# Altium schematic sheet MEZIFREKVENCE.SchDoc(MEZIFREKVENCE)
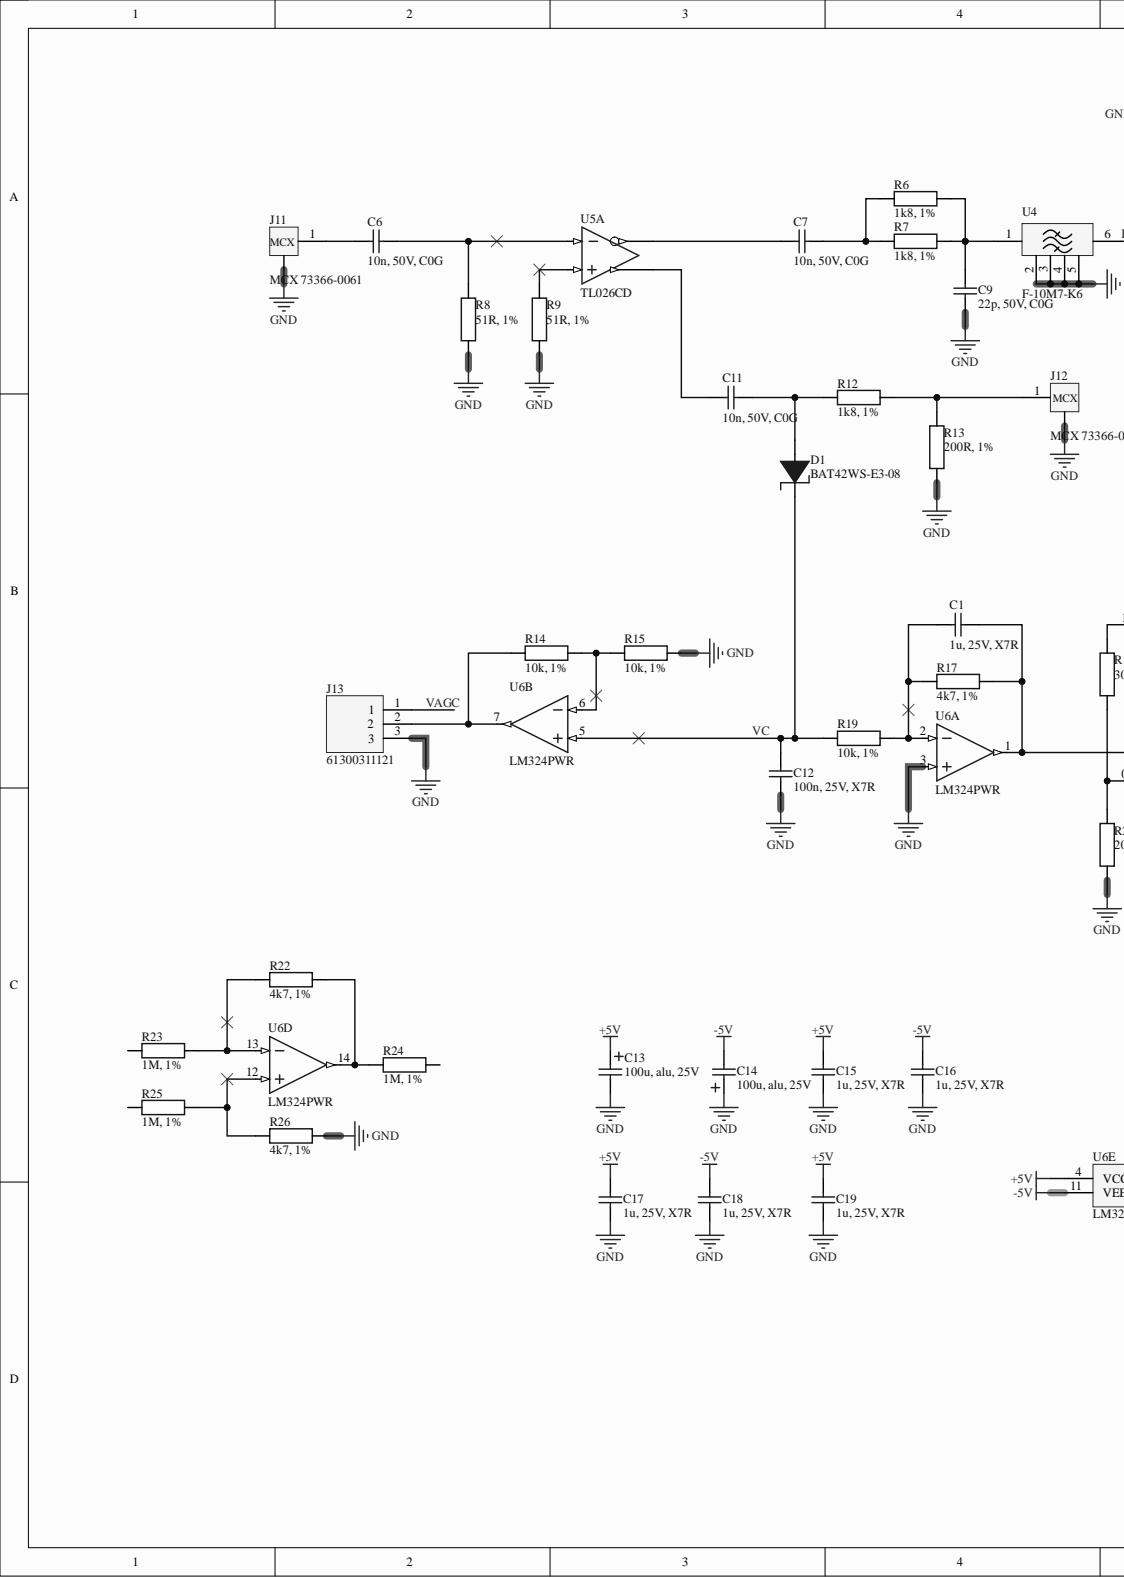
[diagram p0: MEZIFREKVENCE.SchDoc, left]
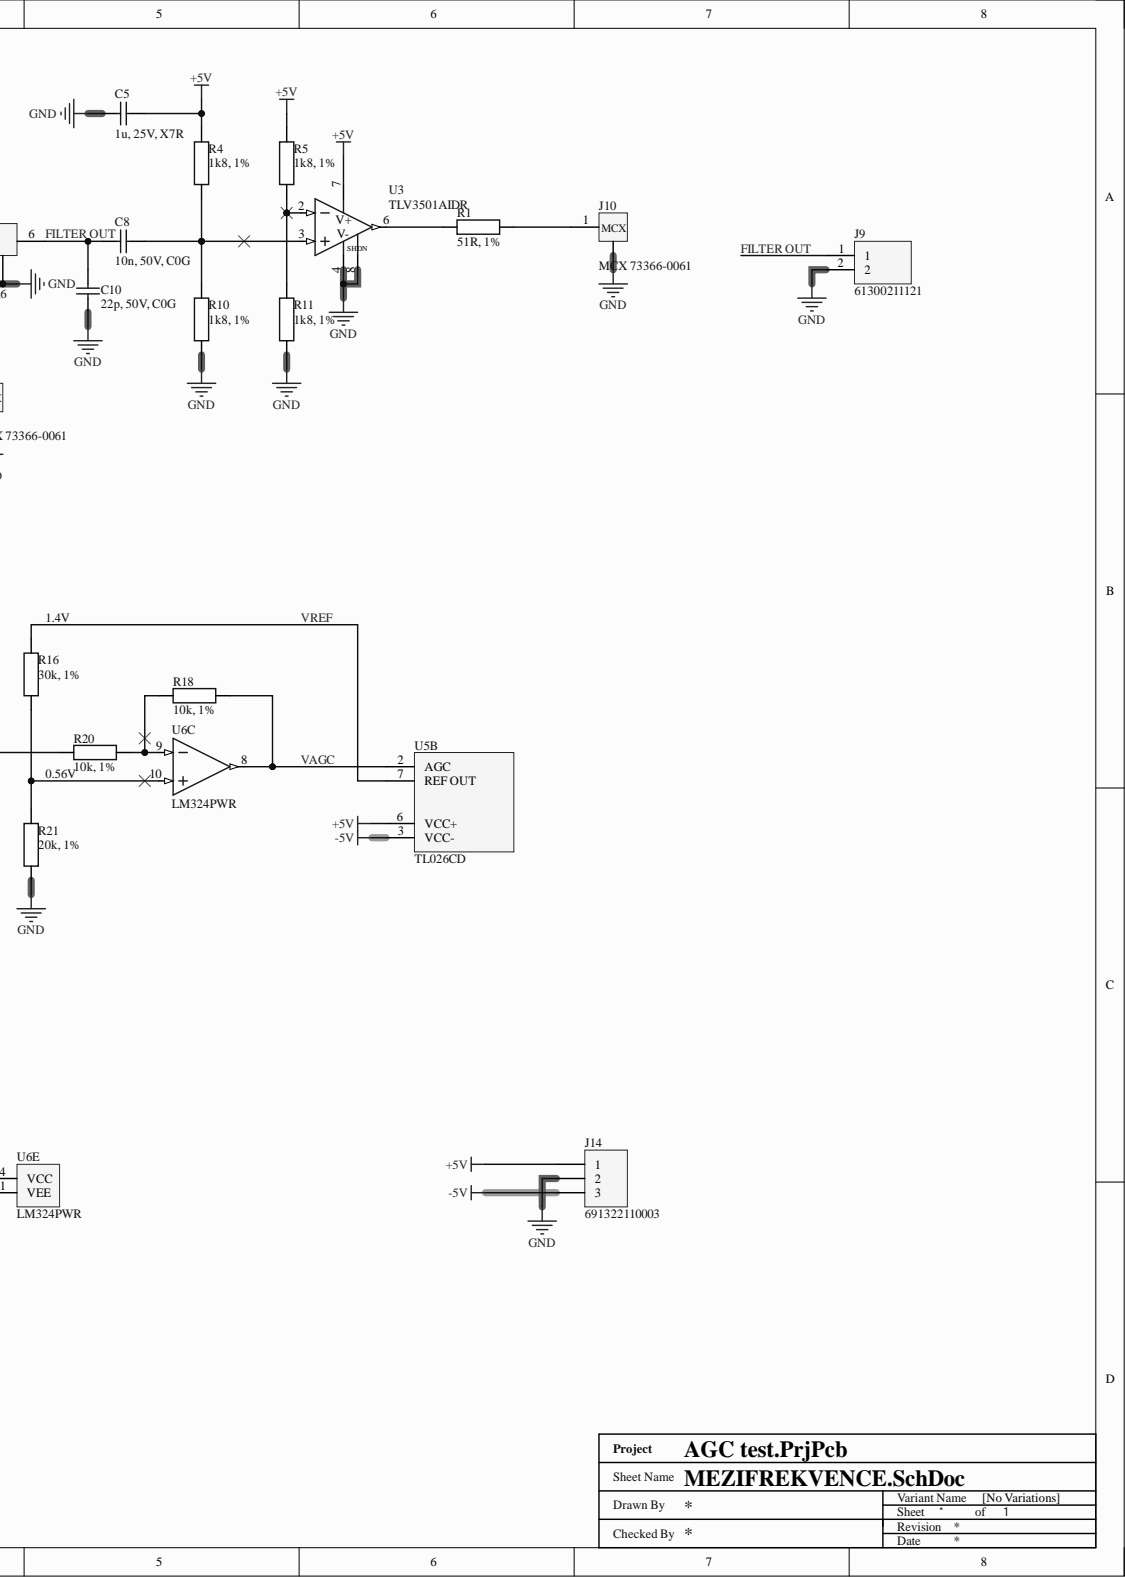
[diagram p1: MEZIFREKVENCE.SchDoc, right]

## Parts
C1  1u, 25V, X7R  Temperature Coefficient: X7R  [SMD0603]
C5  1u, 25V, X7R  [SMD0603]
C6  10n, 50V, C0G  [SMD0603]
C7  10n, 50V, C0G  [SMD0603]
C8  10n, 50V, C0G  [SMD0603]
C9  22p, 50V, C0G  [SMD0603]
C10  22p, 50V, C0G  [SMD0603]
C11  10n, 50V, C0G  [SMD0603]
C12  100n, 25V, X7R  [SMD0603]
C13  100u, alu, 25V  [WCAP-ATLI_6.3x11x2.5]
C14  100u, alu, 25V  Voltage Rating: 25V  [WCAP-ATLI_6.3x11x2.5]
C15  1u, 25V, X7R  Voltage - Rated: 25V  [SMD0603]
C16  1u, 25V, X7R  Temperature Coefficient: X7R  [SMD0603]
C17  1u, 25V, X7R  [SMD0603]
C18  1u, 25V, X7R  Temperature Coefficient: X7R  [SMD0603]
C19  1u, 25V, X7R  Temperature Coefficient: X7R  [SMD0603]
D1  BAT42WS-E3-08  [SOD-323]
J9  61300211121  [Header 2 2.54mm]
J10  MCX 73366-0061  Connector Style: MCX  [73366-0061]
J11  MCX 73366-0061  Connector Style: MCX  [73366-0061]
J12  MCX 73366-0061  Connector Style: MCX  [73366-0061]
J13  61300311121  [Header 3 2.54mm]
J14  691322110003  [691322110003]
R1  51R, 1%  [SMD0603 - R]
R4  1k8, 1%  Tolerance: 1%  [SMD0603 - R]
R5  1k8, 1%  [SMD0603 - R]
R6  1k8, 1%  Tolerance: 1%  [SMD0603 - R]
R7  1k8, 1%  Tolerance: 1%  [SMD0603 - R]
R8  51R, 1%  [SMD0603 - R]
R9  51R, 1%  [SMD0603 - R]
R10  1k8, 1%  Tolerance: 1%  [SMD0603 - R]
R11  1k8, 1%  Tolerance: 1%  [SMD0603 - R]
R12  1k8, 1%  Tolerance: 1%  [SMD0603 - R]
R13  200R, 1%  [SMD0603 - R]
R14  10k, 1%  [SMD0603 - R]
R15  10k, 1%  [SMD0603 - R]
R16  30k, 1%  Resistance Tolerance: 1%  [SMD0603 - R]
R17  4k7, 1%  [SMD0603 - R]
R18  10k, 1%  [SMD0603 - R]
R19  10k, 1%  [SMD0603 - R]
R20  10k, 1%  [SMD0603 - R]
R21  20k, 1%  [SMD0603 - R]
R22  4k7, 1%  [SMD0603 - R]
R23  1M, 1%  [SMD1206 - R]
R24  1M, 1%  [SMD1206 - R]
R25  1M, 1%  [SMD1206 - R]
R26  4k7, 1%  [SMD0603 - R]
U3  TLV3501AIDR  [SOIC08]
U4  F-10M7-K6  [F-10M7-K6]
U5  TL026CD  [SOIC08]  (parts A,B)
U6  LM324PWR  [TSSOP14]  (parts A,B,C,D,E)

## Nets
+5V: C5.2 C13.1 C15.2 C17.2 C19.2 J14.1 R4.2 R5.2 U3.7(V+) U5.6(VCC+) U6.4(VCC)
-5V: C14.2 C16.2 C18.2 J14.3 U5.3(VCC-) U6.11(VEE)
GND: C5.1 C9.1 C10.1 C12.1 C13.2 C14.1 C15.1 C16.1 C17.1 C18.1 C19.1 J9.2 J10.2 J11.2 J12.2 J13.3 J14.2 R8.1 R9.1 R10.1 R11.1 R13.1 R15.2 R21.1 R26.1 U3.4(V-) U3.8 U4.2 U4.3 U4.4 U4.5 U6.3
FILTER OUT: C8.1 C10.2 J9.1 U4.6
VAGC: J13.1 R18.2 U5.2(AGC) U6.8
VC: C12.2 D1.1 R19.1 U6.5
VREF: R16.2 U5.7(REF_OUT)
NetC1_1: C1.1 R17.2 R20.1 U6.1
NetC1_2: C1.2 R17.1 R19.2 U6.2
NetC6_1: C6.1 J11.1
NetC6_2: C6.2 R8.2 U5.8
NetC7_1: C7.1 U5.5
NetC7_2: C7.2 R6.2 R7.2
NetC8_2: C8.2 R4.1 R10.2 U3.3
NetC9_2: C9.2 R6.1 R7.1 U4.1
NetC11_1: C11.1 U5.4
NetC11_2: C11.2 D1.2 R12.1
NetJ10_1: J10.1 R1.2
NetJ12_1: J12.1 R12.2 R13.2
NetJ13_2: J13.2 R14.1 U6.7
NetR1_1: R1.1 U3.6
NetR5_1: R5.1 R11.2 U3.2
NetR9_2: R9.2 U5.1
NetR14_2: R14.2 R15.1 U6.6
NetR16_1: R16.1 R21.2 U6.10
NetR18_1: R18.1 R20.2 U6.9
NetR22_1: R22.1 R23.2 U6.13
NetR22_2: R22.2 R24.1 U6.14
NetR25_2: R25.2 R26.2 U6.12

Not connected: R23 1; R24 2; R25 1

## Library data of the parts
C1 C5 C15-C19 = CGA3E1X7R1E105K080AC: CAP CER 1UF 25V X7R 0603; Manufacturer: TDK Corporation; Tolerance: ±10%; Applications: Automotive; Capacitance: 1u; Category: Capacitors; Component Kind: Standard; ComponentLink1Description: https://product.tdk.com/info/en/catalog/datasheets/mlcc_automotive_general_en.pdf; ComponentLink1URL: https://product.tdk.com/info/en/catalog/datasheets/mlcc_automotive_general_en.pdf; Failure Rate: -; Features: -; Height - Seated (Max): -; Lead Spacing: -; Lead Style: -; Library Name: SMD0603Cap.IntLib; Manufacturer Part Number: CGA3E1X7R1E105K080AC; Mounting Type: Surface Mount, MLCC; Operating Temperature: -55°C ~ 125°C; Package / Case: 0603 (1608 Metric); Packaging: Cut Tape (CT); Part Status: Active; Pricing 1: 1=0.2, 10=0.135, 100=0.0686, 500=0.04696, 1000=0.04154 (USD); Ratings: AEC-Q200; RoHS: RoHS Compliant; Size / Dimension: 0.063" L x 0.032" W (1.60mm x 0.80mm); Stock 1: 109509; Supplier 1: Mouser; Supplier 2: Farnell; Supplier 3: Digi-Key; Supplier Part Number 1: 810-CGA3E1X7R1E105K; Supplier Part Number 2: 2346962; Supplier Part Number 3: 445-6931-1-ND; Thickness (Max): 0.035" (0.90mm)
  C1 C16 C18 C19: Voltage - Rated: 25V
  C5 C17: Temperature Coefficient: X7R; Voltage - Rated: 25V
  C15: Temperature Coefficient: X7R
C6-C8 C11 = GRM1885C1H103JA01D: Multilayer Ceramic Capacitors MLCC - SMD/SMT 0603 50VDC 10000pF 5% C0G; Brand: Murata Electronics; Capacitance - nF: 10 nF; Class: Class 1; CNHTS: 8532241000; Dielectric: C0G (NP0); TARIC: 8532240000; Type: Chip Multilayer Ceramic Capacitor for General Purpose; Capacitance: 10n; Capacitance - pF: 10000 pF; Case Code - in: 0603; Case Code - mm: 1608; Category: Multilayer Ceramic Capacitors MLCC - SMD/SMT; Component Kind: Standard; ComponentLink1Description: http://www.mouser.com/datasheet/2/281/murata_03052018_GRM_Series_1-1310166.pdf; ComponentLink1URL: http://www.mouser.com/datasheet/2/281/murata_03052018_GRM_Series_1-1310166.pdf; Height: 0.8 mm; HTS Code: 8532240020; Length: 1.6 mm; Library Name: SMD0603Cap.IntLib; Manufacturer: Murata Electronics; Manufacturer Part Number: GRM1885C1H103JA01D; Maximum Operating Temperature: + 125 C; Minimum Operating Temperature: - 55 C; Minimum Order Quantity: 1; Package / Case: 0603 (1608 metric); Packaging: Cut Tape; Pricing 1: 1=0.183, 10=0.129, 100=0.065, 500=0.045, 1000=0.039, 4000=0.030, 8000=0.027, 24000=0.025 (EUR); Product: General Type MLCCs; Product Type: Ceramic Capacitors; Reeling: True; RoHS: RoHS Compliant; RoHS - Mouser: Y; Series: GRM; Standard Pack Qty: 4000; Stock 1: 217291; Subcategory: Capacitors; Supplier 1: Mouser; Supplier 2: Farnell; Supplier Part Number 1: 81-GRM1885C1H103JA1D; Supplier Part Number 2: 2456107; Termination: Standard; Termination Style: SMD/SMT; Tolerance: 5 %; Voltage Rating DC: 50 VDC; Width: 0.8 mm
C9 C10 = MC0603N220J500CT: MULTICOMP - MC0603N220J500CT - CAP, MLCC, C0G/NP0, 22PF, 50V, 0603; Capacitance: 22p; Component Kind: Standard; ComponentLink1Description: http://www.farnell.com/datasheets/1901289.pdf; ComponentLink1URL: http://www.farnell.com/datasheets/1901289.pdf; Library Name: SMD0603Cap.IntLib; Manufacturer: MULTICOMP; Manufacturer Part Number: MC0603N220J500CT; Pricing 1: 10=0.0077, 100=0.0065, 500=0.0052, 1000=0.0044, 2000=0.0038 (GBP); RoHS: YES; Stock 1: 184439; Supplier 1: Farnell; Supplier 2: Newark; Supplier Part Number 1: 1759057; Supplier Part Number 2: 94W8766
C12 = 06033C104KAT2A: AVX - 06033C104KAT2A - CAP, MLCC, X7R, 0.1UF, 25V, 0603; Capacitance Tolerance: ± 10%; Ceramic Capacitor Case: 0603 [1608 Metric]; Dielectric Characteristic: X7; Product Range: AVX 0603 MLCC; SVHC: No SVHC (17-Dec-2015)<!--FANDB--><div class="features-and-benefits-container"><div class="features-and-benefits-desc-container"><span class="features-and-benefits-container-desc">SMD Multilayer Ceramic Capacitor, 0603 [1608 Metric], 0.1 µF, 25 V, ± 10%, X7R, AVX 0603 MLCC</span></div><div class="features-and-benefits-description"><span class="features-benefits-value">The 06033C104KAT2A is a SMD Multilayer Ceramic Capacitor with Ni/Sn-plated termination, X7R dielectric and 0603 case. X7R formulations are called temperature stable ceramics and fall into EIA class II materials. X7R is the most popular of this intermediate dielectric constant material. This capacitance change is non-linear. Capacitance for X7R varies under the influence of electrical operating conditions such as voltage and frequency. X7; Voltage Rating: 25; Automotive Qualification Standard: -; Capacitance: 100n; Component Kind: Standard; ComponentLink1Description: http://www.farnell.com/datasheets/2237835.pdf; ComponentLink1URL: http://www.farnell.com/datasheets/2237835.pdf; Library Name: SMD0603Cap.IntLib; Manufacturer: AVX; Manufacturer Part Number: 06033C104KAT2A; MSL: MSL 1 - Unlimited; Operating Temperature Max: 125; Operating Temperature Min: -55; Packaging: Cut Tape; Pricing 1: 10=0.012, 100=0.0096, 500=0.0069, 1000=0.006, 2000=0.0048, 4000=0.0038, 20000=0.0027, 40000=0.0026 (GBP); RoHS: YES; Stock 1: 22809; Supplier 1: Farnell; Supplier 2: Digi-Key; Supplier Part Number 1: 2530141; Supplier Part Number 2: 478-3714-1-ND
C13 C14 = 860010473007: CAP 100 UF 20% 25 V; Manufacturer: Wurth Electronics; Capacitance: 100uF; Life (Hours): 2000Hour; Material: Aluminium; Polarity: Polar; Ripple Current: 209mA; Case/Package: Radial; Category: Aluminum Electrolytic Capacitors; Component Kind: Standard; ComponentLink1Description: https://datasheet.ciiva.com/48693/001280151-da-01-en-wcap-atg8-alu-elec-caps-860010473007-48693591.pdf?src-supplier=Conrad; ComponentLink1URL: https://datasheet.ciiva.com/48693/001280151-da-01-en-wcap-atg8-alu-elec-caps-860010473007-48693591.pdf?src-supplier=Conrad; Diameter: 6.3mm; Height: 11mm; Height - Seated (Max): 0.492inch; Lead Pitch: 0.098inch; Library Name: Capacitors.IntLib; Manufacturer Part Number: 860010473007; Max Operating Temperature: 85°C; Min Operating Temperature: -40°C; Mount: Through Hole; Package Quantity: 1500; Packaging: Tape and Reel; Pricing 1: 1=0.14000, 10=0.13400, 25=0.13400, 50=0.11200, 100=0.09800, 250=0.08700 (USD); REACH SVHC: Unknown; RoHS: Yes; Stock 1: 1494; Supplier 1: Mouser; Supplier 2: Farnell; Supplier 3: Digi-Key; Supplier Part Number 1: 710-860010473007; Supplier Part Number 2: 2465675; Supplier Part Number 3: 732-8630-1-ND; Tolerance: 20%; Voltage Rating (DC): 25V
  C13: Voltage Rating: 25V
D1 = BAT42WS-E3-08: DIODE SCHOTTKY 30V 200MA SOD323; Manufacturer: Vishay Semiconductor Diodes Division; Capacitance @ Vr, F: 7pF @ 1V, 1MHz; Current - Average Rectified (Io): 200mA (DC); Speed: Small Signal =< 200mA (Io), Any Speed; Category: Discrete Semiconductor Products; Component Kind: Standard; ComponentLink1Description: http://www.vishay.com/docs/81813/bat42wsv.pdf; ComponentLink1URL: http://www.vishay.com/docs/81813/bat42wsv.pdf; Diode Type: Schottky; Library Name: Diodes.IntLib; Manufacturer Part Number: BAT42WS-E3-08; Mounting Type: Surface Mount; Operating Temperature - Junction: 125°C (Max); Package / Case: SC-76, SOD-323; Packaging: Tape & Reel (TR); Part Status: Active; Pricing 1: 15000=0.0504 (USD); RoHS: RoHS Compliant; Stock 1: 0; Supplier 1: Digi-Key; Supplier 2: Mouser; Supplier Device Package: SOD-323; Supplier Part Number 1: BAT42WS-E3-08-ND; Supplier Part Number 2: 78-BAT42WS-E3-08
J9 = 61300211121: CONN HEADER 2 POS 2.54; Manufacturer: Wurth Electronics Inc.; Contact Length - Mating: 0.236" (6.00mm); Contact Length - Post: 0.118" (3.00mm); Current Rating: 3A; Material Flammability Rating: UL94 V-0; Number of Positions: 2; Number of Positions Loaded: All; Overall Contact Length: 0.454" (11.54mm); Pitch - Mating: 0.100" (2.54mm); Shrouding: Unshrouded; Style: Board to Board or Cable; Voltage Rating: 250V; Applications: -; Category: Connectors, Interconnects; Component Kind: Standard; ComponentLink1Description: https://katalog.we-online.de/em/datasheet/6130xx11121.pdf; ComponentLink1URL: https://katalog.we-online.de/em/datasheet/6130xx11121.pdf; Connector Type: Header; Contact Finish - Mating: Gold; Contact Finish - Post: -; Contact Finish Thickness - Mating: -; Contact Finish Thickness - Post: -; Contact Material: Copper Alloy; Contact Shape: Square; Contact Type: Male Pin; Fastening Type: Push-Pull; Features: -; Ingress Protection: -; Insulation Color: Black; Insulation Height: 0.100" (2.54mm); Insulation Material: Polybutylene Terephthalate (PBT); Library Name: Connectors.IntLib; Manufacturer Part Number: 61300211121; Mated Stacking Heights: -; Mounting Type: Through Hole; Number of Rows: 1; Operating Temperature: -40°C ~ 125°C; Packaging: Bulk; Part Status: Active; Pricing 1: 1=0.13, 10=0.106, 25=0.088, 50=0.074, 100=0.067, 1000=0.061 (USD); RoHS: RoHS Compliant; Row Spacing - Mating: -; Stock 1: 164911; Supplier 1: Mouser; Supplier 2: Farnell; Supplier 3: Digi-Key; Supplier Part Number 1: 710-61300211121; Supplier Part Number 2: 2356153; Supplier Part Number 3: 732-5315-ND; Termination: Solder
J10-J12 = 73366-0061: CONN MCX JACK STR 50 OHM PCB; Manufacturer: Molex, LLC; Manufacturer Part Number: 0733660061; Body Finish: Gold; Body Material: Brass; Center Contact Material: Beryllium Copper; Connector Type: Jack, Female Socket; Contact Termination: Solder; Dielectric Material: Polytetrafluoroethylene (PTFE); Impedance: 50 Ohm; Mating Cycles: 500; Cable Group: -; Category: Connectors, Interconnects; Center Contact Plating: Gold; Component Kind: Standard; ComponentLink1Description: https://www.molex.com/pdm_docs/sd/733660061_sd.pdf; ComponentLink1URL: https://www.molex.com/pdm_docs/sd/733660061_sd.pdf; Fastening Type: Snap-On; Features: -; Housing Color: Gold; Includes: -; Ingress Protection: -; Insertion Loss: -; Library Name: Connectors.IntLib; Mounting Feature: -; Mounting Type: Through Hole; Operating Temperature: -55°C ~ 155°C; Packaging: Tray; Part Status: Active; Pricing 1: 1=1.95, 10=1.765, 25=1.6572, 50=1.5854, 100=1.5133, 250=1.36916, 500=1.26106, 1000=1.0809, 2500=1.00884 (USD); RoHS: RoHS Compliant; Shield Termination: Solder; Stock 1: 39394; Supplier 1: Mouser; Supplier 2: Digi-Key; Supplier 3: Farnell; Supplier Part Number 1: 538-73366-0061; Supplier Part Number 2: WM5541-ND; Supplier Part Number 3: 1909245
J13 = 61300311121: CONN HEADER 3 POS 2.54; Manufacturer: Wurth Electronics Inc.; Contact Length - Mating: 0.236" (6.00mm); Contact Length - Post: 0.118" (3.00mm); Current Rating: 3A; Material Flammability Rating: UL94 V-0; Number of Positions: 3; Number of Positions Loaded: All; Overall Contact Length: 0.454" (11.54mm); Pitch - Mating: 0.100" (2.54mm); Shrouding: Unshrouded; Style: Board to Board or Cable; Voltage Rating: 250V; Applications: -; Category: Connectors, Interconnects; Component Kind: Standard; ComponentLink1Description: https://katalog.we-online.de/em/datasheet/6130xx11121.pdf; ComponentLink1URL: https://katalog.we-online.de/em/datasheet/6130xx11121.pdf; Connector Type: Header; Contact Finish - Mating: Gold; Contact Finish - Post: -; Contact Finish Thickness - Mating: -; Contact Finish Thickness - Post: -; Contact Material: Copper Alloy; Contact Shape: Square; Contact Type: Male Pin; Fastening Type: Push-Pull; Features: -; Ingress Protection: -; Insulation Color: Black; Insulation Height: 0.100" (2.54mm); Insulation Material: Polybutylene Terephthalate (PBT); Library Name: Connectors.IntLib; Manufacturer Part Number: 61300311121; Mated Stacking Heights: -; Mounting Type: Through Hole; Number of Rows: 1; Operating Temperature: -40°C ~ 125°C; Packaging: Bulk; Part Status: Active; Pricing 1: 1=0.13, 10=0.106, 25=0.088, 50=0.074, 100=0.067, 1000=0.061 (USD); RoHS: RoHS Compliant; Row Spacing - Mating: -; Stock 1: 222317; Supplier 1: Mouser; Supplier 2: Farnell; Supplier 3: Digi-Key; Supplier Part Number 1: 710-61300311121; Supplier Part Number 2: 2356154; Supplier Part Number 3: 732-5316-ND; Termination: Solder
J14 = 691322110003: WR-TBL Serie 3221 - 3.50 mm Horizontal PCB Header, 03p; Pitch: 3.5 mm; Component Kind: Standard; ComponentLink1Description: Manufacturer; ComponentLink1URL: http://www.we-online.de; ComponentLink2Description: Datasheet; ComponentLink2URL: http://katalog.we-online.de/em/datasheet/6913221100xx.pdf; Library Name: Connectors.IntLib; Part Number: 691322110003; Pin Number: 03p; Published Date: Jan 2017; Supplier 1: Digi-Key; Supplier 2: Farnell; Supplier Part Number 1: 732-2770-ND; Supplier Part Number 2: 1841316; Publisher: Wurth Elektronik
R1 R8 R9 = CRCW060351R0FKEA: RES SMD 51 OHM 1% 1/10W 0603; Manufacturer: Vishay Dale; Power (Watts): 0.1W, 1/10W; Temperature Coefficient: ±100ppm/°C; Category: Resistors; Component Kind: Standard; ComponentLink1Description: http://www.vishay.com/docs/20035/dcrcwe3.pdf; ComponentLink1URL: http://www.vishay.com/docs/20035/dcrcwe3.pdf; Composition: Thick Film; Failure Rate: -; Features: Automotive AEC-Q200; Height - Seated (Max): 0.020" (0.50mm); Library Name: SMD0603Res.IntLib; Manufacturer Part Number: CRCW060351R0FKEA; Operating Temperature: -55°C ~ 155°C; Package / Case: 0603 (1608 Metric); Packaging: Cut Tape (CT); Part Status: Active; Pricing 1: 1=0.1, 10=0.042, 100=0.017, 1000=0.00764, 2500=0.00663 (USD); Resistance: 51R; RoHS: RoHS Compliant; Size / Dimension: 0.063" L x 0.033" W (1.60mm x 0.85mm); Stock 1: 21691; Supplier 1: Mouser; Supplier 2: Farnell; Supplier 3: Digi-Key; Supplier Device Package: 0603; Supplier Part Number 1: 71-CRCW0603-51-E3; Supplier Part Number 2: 1652889; Supplier Part Number 3: 541-51.0HCT-ND; Tolerance: ±1%
R4-R7 R10-R12 = RC0603FR-071K8L: Res Thick Film 0603 1.8K Ohm 1% 0.1W(1/10W) ±100ppm/C Molded SMD Paper T/R; Manufacturer: Yageo; Max Power Dissipation: 100mW; Temperature Coefficient: 100ppm/°C; Voltage Rating: 50V; Case Code (Imperial): 0603; Case Code (Metric): 1608; Case/Package: 0603; Category: Chip SMD Resistors; Component Kind: Standard; ComponentLink1Description: https://datasheet.ciiva.com/11808/0900766b80f96399-11808105.pdf?src-supplier=RSComponents; ComponentLink1URL: https://datasheet.ciiva.com/11808/0900766b80f96399-11808105.pdf?src-supplier=RSComponents; ComponentLink2Description: https://datasheet.ciiva.com/2519/pyu-r-int-thick-7-2519189.pdf?src-supplier=Digi-Key; ComponentLink2URL: https://datasheet.ciiva.com/2519/pyu-r-int-thick-7-2519189.pdf?src-supplier=Digi-Key; ComponentLink3Description: https://datasheet.ciiva.com/7746/443971-7746277.pdf?src-supplier=Element14; ComponentLink3URL: https://datasheet.ciiva.com/7746/443971-7746277.pdf?src-supplier=Element14; ComponentLink4Description: https://datasheet.ciiva.com/2519/pyu-r-int-thick-7-2519190.pdf?src-supplier=Digi-Key; ComponentLink4URL: https://datasheet.ciiva.com/2519/pyu-r-int-thick-7-2519190.pdf?src-supplier=Digi-Key; ComponentLink5Description: https://datasheet.ciiva.com/7746/443971-7746278.pdf?src-supplier=Element14; ComponentLink5URL: https://datasheet.ciiva.com/7746/443971-7746278.pdf?src-supplier=Element14; ComponentLink6Description: https://datasheet.ciiva.com/12558/rc0603-272842-12558694.pdf?src-supplier=Mouser; ComponentLink6URL: https://datasheet.ciiva.com/12558/rc0603-272842-12558694.pdf?src-supplier=Mouser; ComponentLink7Description: https://datasheet.ciiva.com/2519/pyu-r-int-thick-7-2519191.pdf?src-supplier=Digi-Key; ComponentLink7URL: https://datasheet.ciiva.com/2519/pyu-r-int-thick-7-2519191.pdf?src-supplier=Digi-Key; Composition: Thick Film; Depth: 0.8mm; Features: Moisture Resistant; Height: 0.45mm; Lead Free: Lead Free; Length: 1.6mm; Library Name: SMD0603Res.IntLib; Manufacturer Part Number: RC0603FR-071K8L; Max Operating Temperature: 155°C; Military Standard: Not; Min Operating Temperature: -55°C; Number of Pins: 2; Number of Terminations: 2; Package Quantity: 5000; Packaging: Tape and Reel; Power Rating: 100mW; Pricing 1: 1=0,10000, 10=0,01800, 100=0,00600, 1000=0,00500, 5000=0,00300, 10000=0,00300 (USD); REACH SVHC: No SVHC; Resistance: 1k8; RoHS: Yes; Stock 1: 505177; Supplier 1: Mouser; Supplier 2: Digi-Key; Supplier 3: Farnell; Supplier Part Number 1: 603-RC0603FR-071K8L; Supplier Part Number 2: 311-1.80KHRCT-ND; Supplier Part Number 3: 2693664; Termination: SMD/SMT; Voltage Rating (DC): 50V; Width: 0.8mm
  R5: Tolerance: 1%
R13 = RC0603FR-13200RL: Res Thick Film 0603 200 Ohm 1% 0.1W(1/10W) ±100ppm/C Molded SMD Paper T/R; Manufacturer: Yageo; Power Rating: 100mW; Temperature Coefficient: 100ppm/°C; Voltage Rating: 50V; Case Code (Imperial): 0603; Case Code (Metric): 1608; Case/Package: 0603; Category: Chip SMD Resistors; Component Kind: Standard; ComponentLink1Description: https://datasheet.ciiva.com/12562/rc0603-272842-12562026.pdf?src-supplier=Mouser; ComponentLink1URL: https://datasheet.ciiva.com/12562/rc0603-272842-12562026.pdf?src-supplier=Mouser; Construction: Rectangular; Contact Plating: Tin,Matte; Depth: 0.8mm; Height: 0.45mm; Length: 1.6mm; Library Name: SMD0603Res.IntLib; Manufacturer Part Number: RC0603FR-13200RL; Max Operating Temperature: 155°C; Military Standard: Not; Min Operating Temperature: -55°C; Packaging: Tape and Reel; Pricing 1: 1=0,10000, 10=0,01800, 50=0,01800, 100=0,00600, 1000=0,00500, 10000=0,00400 (USD); Radiation Hardening: No; Resistance: 200R; Resistor Type: Thick Film; RoHS: Yes; RoHS Compliant: Yes; Series: RC; Stock 1: 45325; Supplier 1: Mouser; Supplier 2: Arrow Electronics; Supplier Part Number 1: 603-RC0603FR-13200RL; Supplier Part Number 2: RC0603FR-13200RL; Termination: SMD/SMT; Tolerance: 1%; Width: 0.8mm
R14 R15 R18-R20 = RC0603FR-0710KL: YAGEO (PHYCOMP) - RC0603FR-0710KL - RES, THICK FILM, 10K, 1%, 0.1W, 0603; Power Rating: 100; Product Range: RC Series; Resistor Case Style: 0603 [1608 Metric]; SVHC: No SVHC (20-Jun-2016); Temperature Coefficient: ± 100; Voltage Rating: 50; Automotive Qualification Standard: -; Component Kind: Standard; ComponentLink1Description: http://past.yageo.com/pdf/PYu-R_Packing_6.pdf; ComponentLink1URL: http://past.yageo.com/pdf/PYu-R_Packing_6.pdf; ComponentLink2Description: http://www.farnell.com/datasheets/2167052.pdf; ComponentLink2URL: http://www.farnell.com/datasheets/2167052.pdf; Library Name: SMD0603Res.IntLib; Manufacturer: YAGEO (PHYCOMP); Manufacturer Part Number: RC0603FR-0710KL; MSL: MSL 1 - Unlimited<!--FANDB--><div class="features-and-benefits-container"><div class="features-and-benefits-desc-container"><span class="features-and-benefits-container-desc">SMD Chip Resistor, Thick Film, 10 kohm, 50 V, 0603 [1608 Metric], 100 mW, ± 1; Packaging: Tape & Reel; Pricing 1: 5000=0.0025, 25000=0.0014, 50000=0.0013 (GBP); Resistance: 10k; Resistance Tolerance: ± 1%; Resistor Element Material: Thick Film; RoHS: YES; Stock 1: 9995; Supplier 1: Mouser; Supplier 2: Farnell; Supplier 3: Digi-Key; Supplier Part Number 1: 603-RC0603FR-0710KL; Supplier Part Number 2: 2421850; Supplier Part Number 3: 311-10.0KHRCT-ND
R16 = RC0603FR-0730KL: YAGEO - RC0603FR-0730KL - RES, THICK FILM, 30K, 1%, 0.1W, 0603, REEL; Max Power Dissipation: 100mW; Temperature Coefficient: 100ppm/°C; Voltage Rating: 50V; Case Code (Imperial): 0603; Case Code (Metric): 1608; Case/Package: 0603; Category: Chip SMD Resistors; Component Kind: Standard; ComponentLink1Description: https://datasheet.ciiva.com/12558/rc0603-272842-12558744.pdf?src-supplier=Mouser; ComponentLink1URL: https://datasheet.ciiva.com/12558/rc0603-272842-12558744.pdf?src-supplier=Mouser; ComponentLink2Description: https://datasheet.ciiva.com/46395/443971-46395003.pdf?src-supplier=Element14; ComponentLink2URL: https://datasheet.ciiva.com/46395/443971-46395003.pdf?src-supplier=Element14; Construction: Rectangular; Depth: 0.8mm; Features: Moisture Resistant; Height: 0.45mm; Lead Free: Lead Free; Length: 1.6mm; Library Name: SMD0603Res.IntLib; Manufacturer: Yageo; Manufacturer Part Number: RC0603FR-0730KL; Max Operating Temperature: 155°C; Military Standard: Not; Min Operating Temperature: -55°C; Number of Pins: 2; Number of Terminations: 2; Package Quantity: 5000; Packaging: Tape and Reel; Power Rating: 100mW; Pricing 1: 1=0.10000, 10=0.01800, 50=0.01800, 100=0.00600, 1000=0.00500, 10000=0.00300 (USD); REACH SVHC: Unknown; Resistance: 30k; Resistor Type: Thick Film; RoHS: Yes; RoHS Compliant: Yes; Series: RC; Size Code: 0603; Stock 1: 345417; Supplier 1: Mouser; Supplier 2: Farnell; Supplier 3: Digi-Key; Supplier Part Number 1: 603-RC0603FR-0730KL; Supplier Part Number 2: 3495811; Supplier Part Number 3: 311-30.0KHRCT-ND; Termination: SMD/SMT; Tolerance: 1%; Voltage Rating (DC): 50V; Width: 0.8mm
R17 R22 R26 = AC0603FR-074K7L: RES SMD 4.7K OHM 1% 1/10W 0603; Manufacturer: Yageo; Power (Watts): 0.1W, 1/10W; Temperature Coefficient: ±100ppm/°C; Category: Resistors; Component Kind: Standard; ComponentLink1Description: http://www.yageo.com/NewPortal/yageodocoutput?fileName=/pdf/R-Chip/PYu-AC_51_RoHS_L_6.pdf; ComponentLink1URL: http://www.yageo.com/NewPortal/yageodocoutput?fileName=/pdf/R-Chip/PYu-AC_51_RoHS_L_6.pdf; Composition: Thick Film; Failure Rate: -; Features: Automotive AEC-Q200, Moisture Resistant; Height - Seated (Max): 0.022" (0.55mm); Library Name: SMD0603Res.IntLib; Manufacturer Part Number: AC0603FR-074K7L; Operating Temperature: -55°C ~ 155°C; Package / Case: 0603 (1608 Metric); Packaging: Cut Tape (CT); Part Status: Active; Pricing 1: 1=0.1, 10=0.025, 100=0.01, 1000=0.00448, 2500=0.00389 (USD); Resistance: 4k7; RoHS: RoHS Compliant; Size / Dimension: 0.063" L x 0.032" W (1.60mm x 0.80mm); Stock 1: 391581; Supplier 1: Mouser; Supplier 2: Digi-Key; Supplier 3: Future Electronics; Supplier Device Package: 0603; Supplier Part Number 1: 603-AC0603FR-074K7L; Supplier Part Number 2: YAG3613CT-ND; Supplier Part Number 3: AC0603FR-074K7L-CUTT; Tolerance: ±1%
R21 = AC0603FR-0720KL: RES SMD 20K OHM 1% 1/10W 0603; Manufacturer: Yageo; Power (Watts): 0.1W, 1/10W; Temperature Coefficient: ±100ppm/°C; Category: Resistors; Component Kind: Standard; ComponentLink1Description: http://www.yageo.com/NewPortal/yageodocoutput?fileName=/pdf/R-Chip/PYu-AC_51_RoHS_L_6.pdf; ComponentLink1URL: http://www.yageo.com/NewPortal/yageodocoutput?fileName=/pdf/R-Chip/PYu-AC_51_RoHS_L_6.pdf; Composition: Thick Film; Failure Rate: -; Features: Automotive AEC-Q200, Moisture Resistant; Height - Seated (Max): 0.022" (0.55mm); Library Name: SMD0603Res.IntLib; Manufacturer Part Number: AC0603FR-0720KL; Operating Temperature: -55°C ~ 155°C; Package / Case: 0603 (1608 Metric); Packaging: Cut Tape (CT); Part Status: Active; Pricing 1: 1=0.1, 10=0.025, 100=0.01, 1000=0.00448, 2500=0.00389 (USD); Resistance: 20k; RoHS: RoHS Compliant; Size / Dimension: 0.063" L x 0.032" W (1.60mm x 0.80mm); Stock 1: 120000; Supplier 1: Mouser; Supplier 2: Digi-Key; Supplier Device Package: 0603; Supplier Part Number 1: 603-AC0603FR-0720KL; Supplier Part Number 2: YAG3577CT-ND; Tolerance: ±1%
R23-R25 = ERJ-8ENF1004V: RES SMD 1M OHM 1% 1/4W 1206; Manufacturer: Panasonic Electronic Components; Power (Watts): 0.25W, 1/4W; Temperature Coefficient: ±100ppm/°C; Category: Resistors; Component Kind: Standard; ComponentLink1Description: https://industrial.panasonic.com/cdbs/www-data/pdf/RDA0000/AOA0000C304.pdf; ComponentLink1URL: https://industrial.panasonic.com/cdbs/www-data/pdf/RDA0000/AOA0000C304.pdf; Composition: Thick Film; Failure Rate: -; Features: Automotive AEC-Q200; Height - Seated (Max): 0.028" (0.70mm); Library Name: SMD1206Res.IntLib; Manufacturer Part Number: ERJ-8ENF1004V; Operating Temperature: -55°C ~ 155°C; Package / Case: 1206 (3216 Metric); Packaging: Cut Tape (CT); Part Status: Active; Pricing 1: 1=0.11, 10=0.097, 100=0.0373, 1000=0.01641, 2500=0.01417 (USD); Resistance: 1M; RoHS: RoHS Compliant; Size / Dimension: 0.126" L x 0.063" W (3.20mm x 1.60mm); Stock 1: 216046; Supplier 1: Mouser; Supplier 2: Farnell; Supplier 3: Digi-Key; Supplier Device Package: 1206; Supplier Part Number 1: 667-ERJ-8ENF1004V; Supplier Part Number 2: 2307298; Supplier Part Number 3: P1.00MFCT-ND; Tolerance: ±1%
U3 = TLV3501AIDR: Rail-to-Rail, High-Speed Comparator in Microsize Packages; Manufacturer: Texas Instruments; Common Mode Rejection Ratio: 70dB; Hysteresis: 6mV; Input Bias Current: 10pA; Input Offset Voltage (Vos): 6.5mV; Max Supply Current: 5mA; Max Supply Voltage: 5.5V; Min Supply Voltage: 2.7V; Number of Channels: 1; Output Current: 74mA; Output Type: TTL,PUSH-PULL; Power Supply Rejection Ratio (PSRR): 100dB; Propagation Delay: 10ns; Response Time: 0.0045us; Case/Package: SOIC; Category: Amplifiers - Op Amps, Buffer, Instrumentation; Component Kind: Standard; ComponentLink1Description: https://datasheet.ciiva.com/1830/tlv3501-1830016.pdf?src-supplier=Digi-Key; ComponentLink1URL: https://datasheet.ciiva.com/1830/tlv3501-1830016.pdf?src-supplier=Digi-Key; ComponentLink2Description: https://datasheet.ciiva.com/14392/tlv3501-14392108.pdf?src-supplier=Avnet; ComponentLink2URL: https://datasheet.ciiva.com/14392/tlv3501-14392108.pdf?src-supplier=Avnet; Lead Free: Lead Free; Library Name: Lib1.IntLib; Manufacturer Part Number: TLV3501AIDR; Max Input Current: 10pA; Max Operating Temperature: 125°C; Min Operating Temperature: -40°C; Mount: Surface Mount; Nominal Supply Current: 5mA; Number of Elements: 1; Number of Pins: 8; Package Quantity: 2500; Packaging: Tape and Reel; Pricing 1: 1=3.15000, 10=2.83000, 50=2.68000, 100=2.32000, 1000=1.73000, 10000=1.72000 (USD); Quiescent Current: 5mA; Radiation Hardening: No; RoHS: Yes; RoHS Compliant: Yes; Series: -; Stock 1: 2140; Supplier 1: Mouser; Supplier 2: Digi-Key; Supplier 3: Arrow Electronics; Supplier Part Number 1: 595-TLV3501AIDR; Supplier Part Number 2: 296-43611-1-ND; Supplier Part Number 3: TLV3501AIDR; Supply Current: 5mA; Technology: CMOS; Type: General Purpose; Weight: 0.002677oz
U4 = F-10M7-K6: 10.7 MHz crystal band-pass filter, 8 poles  - Bandwidth (-3dB): 12 KHz  - Selectivity: 90 dB  - Impedance: 900 Ω  - Dimensions: 38 x 18 mm, h 12 mm; Component Kind: Standard; Library Name: RF components.SchLib
U5 = TL026CD: Differential High-Frequency Amplifier with AGC 8-SOIC 0 to 70; Manufacturer: Texas Instruments; -3db Bandwidth: 50MHz; Common Mode Rejection Ratio: 60dB; Dual Supply Voltage: 6V; Input Bias Current: 30uA; Max Dual Supply Voltage: 8V; Max Power Dissipation: 725mW; Min Dual Supply Voltage: 3V; Nominal Supply Current: 27mA; Number of Amplifiers: 1; Operating Supply Current: 22mA; Operating Supply Voltage: 16V; Output Current per Channel: 4mA; Voltage Gain: 38.59dB; Bandwidth: 50MHz; Case/Package: D; Category: Amplifiers - Op Amps, Buffer, Instrumentation; Component Kind: Standard; ComponentLink1Description: https://datasheet.ciiva.com/26857/tl026cd-26857756.pdf?src-supplier=IIIC; ComponentLink1URL: https://datasheet.ciiva.com/26857/tl026cd-26857756.pdf?src-supplier=IIIC; ComponentLink2Description: https://datasheet.ciiva.com/28430/slfs007a-28430037.pdf?src-supplier=Avnet+Europe; ComponentLink2URL: https://datasheet.ciiva.com/28430/slfs007a-28430037.pdf?src-supplier=Avnet+Europe; ComponentLink3Description: https://datasheet.ciiva.com/26815/getdatasheetpartid-48553-26815191.pdf?src-supplier=Verical; ComponentLink3URL: https://datasheet.ciiva.com/26815/getdatasheetpartid-48553-26815191.pdf?src-supplier=Verical; ComponentLink4Description: https://datasheet.ciiva.com/1488/1835114-1488075.pdf?src-supplier=Element14; ComponentLink4URL: https://datasheet.ciiva.com/1488/1835114-1488075.pdf?src-supplier=Element14; ComponentLink5Description: https://datasheet.ciiva.com/1824/tl026-1824398.pdf?src-supplier=Digi-Key; ComponentLink5URL: https://datasheet.ciiva.com/1824/tl026-1824398.pdf?src-supplier=Digi-Key; ComponentLink6Description: https://datasheet.ciiva.com/48712/001011461-da-01-en-agc-video-amplifier-tl026cd-soic-8-tid-48712348.pdf?src-supplier=Conrad; ComponentLink6URL: https://datasheet.ciiva.com/48712/001011461-da-01-en-agc-video-amplifier-tl026cd-soic-8-tid-48712348.pdf?src-supplier=Conrad; Height: 1.58mm; Lead Free: Lead Free; Length: 4.9mm; Library Name: Lib1.IntLib; Manufacturer Part Number: TL026CD; Max Operating Temperature: 70°C; Max Supply Voltage: 8V; Min Operating Temperature: 0°C; Min Supply Voltage: 3V; Number of Channels: 1; Number of Circuits: 1; Number of Elements: 1; Number of Pins: 8; Package Quantity: 75; Packaging: Rail/Tube; Power Dissipation: 725mW; Pricing 1: 1=2.57000, 10=2.46000, 50=2.46000, 100=2.45000, 1000=2.45000, 10000=1.45000 (USD); Radiation Hardening: No; REACH SVHC: No SVHC; RoHS: Yes; RoHS Compliant: Yes; Series: TL026; Stock 1: 1672; Supplier 1: Mouser; Supplier 2: Farnell; Supplier 3: Digi-Key; Supplier Part Number 1: 595-TL026CD; Supplier Part Number 2: 1459444; Supplier Part Number 3: 296-11818-5-ND; Supply Current: 27mA; Technology: JFET; Weight: 0.002561oz; Width: 3.91mm
U6 = LM324PWR: OP-AMP, 1.2MHZ, 0.5V/ US, TSSOP-14; Manufacturer: TEXAS INSTRUMENTS; Amplifer Supply Voltage: ± 1.5V to ± 16; Amplifier Case Style: TSSOP; Bandwidth: 1.2; Filter Terminals: SMD; Input Bias Current: 250000; No. of Amplifiers: 4; No. of Pins: 14; Op Amp Type: High Gain; Output Current: 20; Quiescent Current: 0.3; Slew Rate: 0.5; Supply Voltage Max: 32; Supply Voltage Min: 3; SVHC: No SVHC (17-Dec-2014); Component Kind: Standard; ComponentLink1Description: http://www.farnell.com/datasheets/1877076.pdf; ComponentLink1URL: http://www.farnell.com/datasheets/1877076.pdf; ComponentLink2Description: http://www.farnell.com/datasheets/378369.pdf; ComponentLink2URL: http://www.farnell.com/datasheets/378369.pdf; Library Name: Lib1.IntLib; Manufacturer Part Number: LM324PWR; MSL: MSL 1 - Unlimited; Operating Temperature Max: 70; Operating Temperature Min: 0; Operating Temperature Range: 0°C to +70; Packaging: Cut Tape; Pricing 1: 5=0,171, 50=0,15, 250=0,126, 500=0,125, 1000=0,125 (EUR); Pricing 3: 1=0,24, 10=0,23, 100=0,23, 250=0,22, 500=0,21, 1000=0,21, 3000=0,171, 10000=0,157 (EUR); RoHS: YES; Stock 1: 1889; Stock 3: 1204; Supplier 1: Mouser; Supplier 2: Farnell; Supplier Part Number 1: 595-LM324PWR; Supplier Part Number 2: 2342289; Supply Voltage Range: ± 1.5V to ± 16
